# Altium schematic sheet chaves.SchDoc
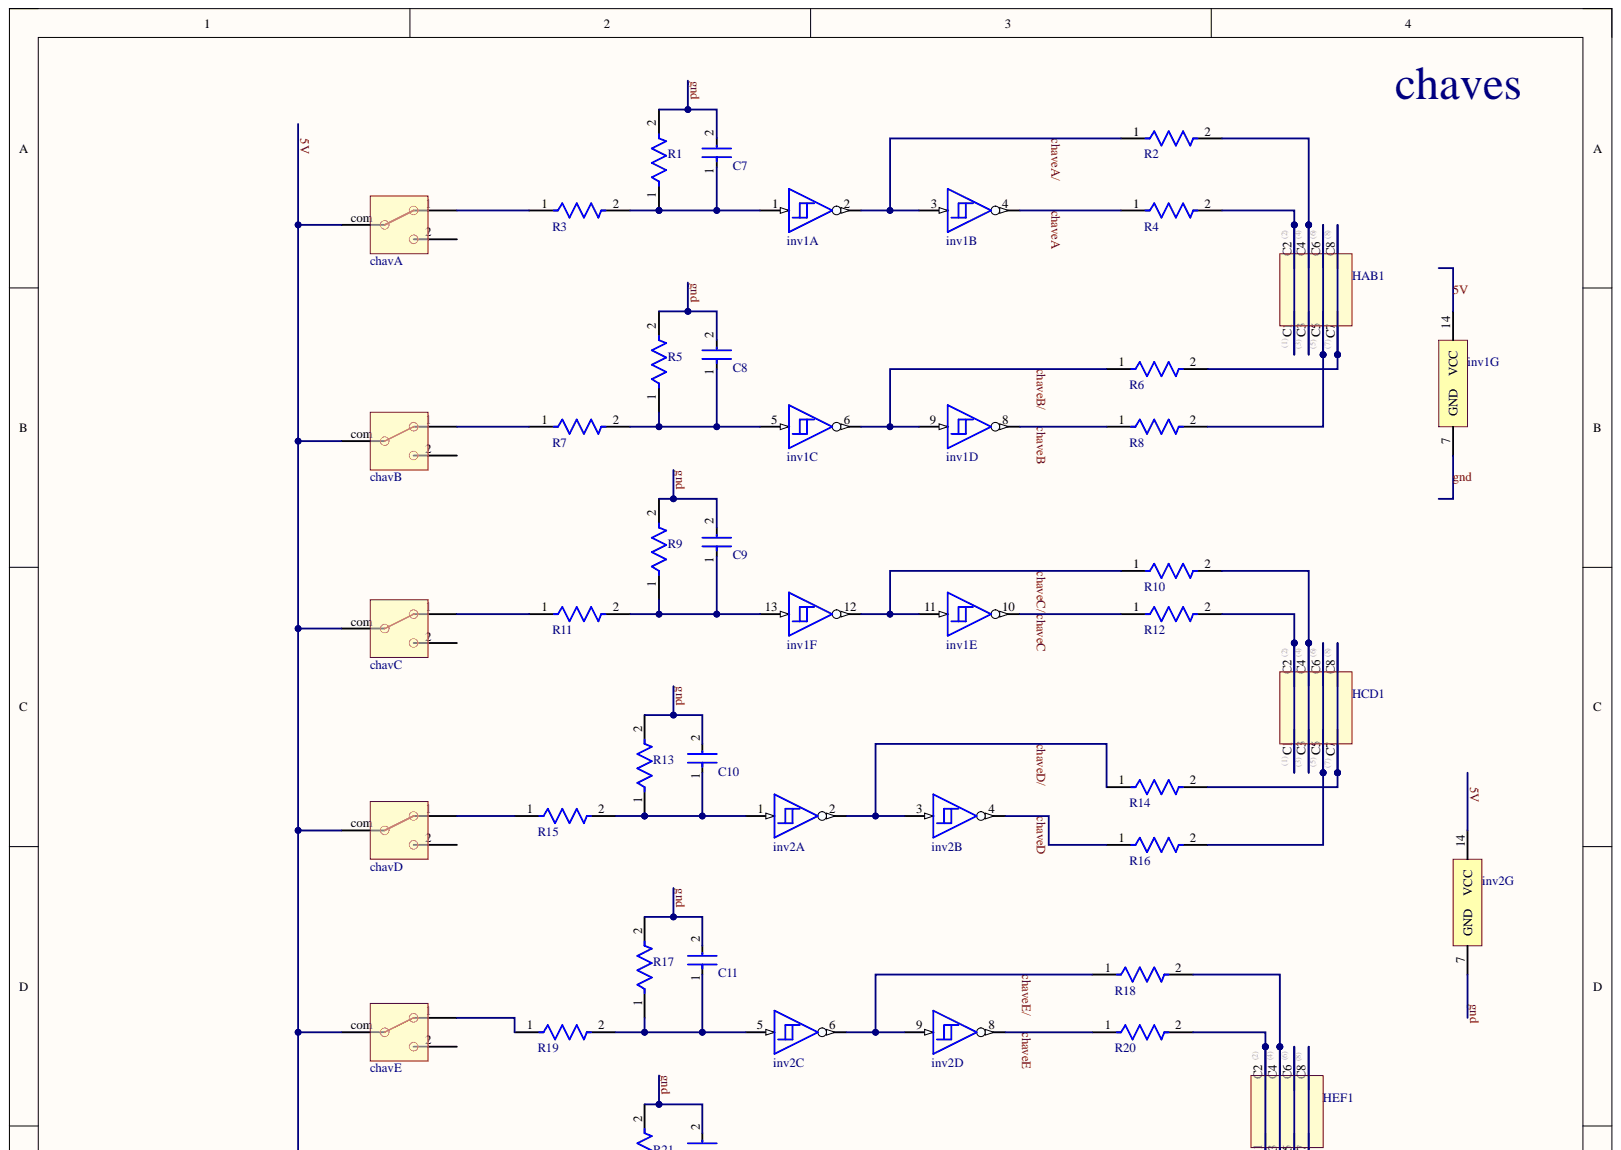
[diagram p0: chaves.SchDoc, top]
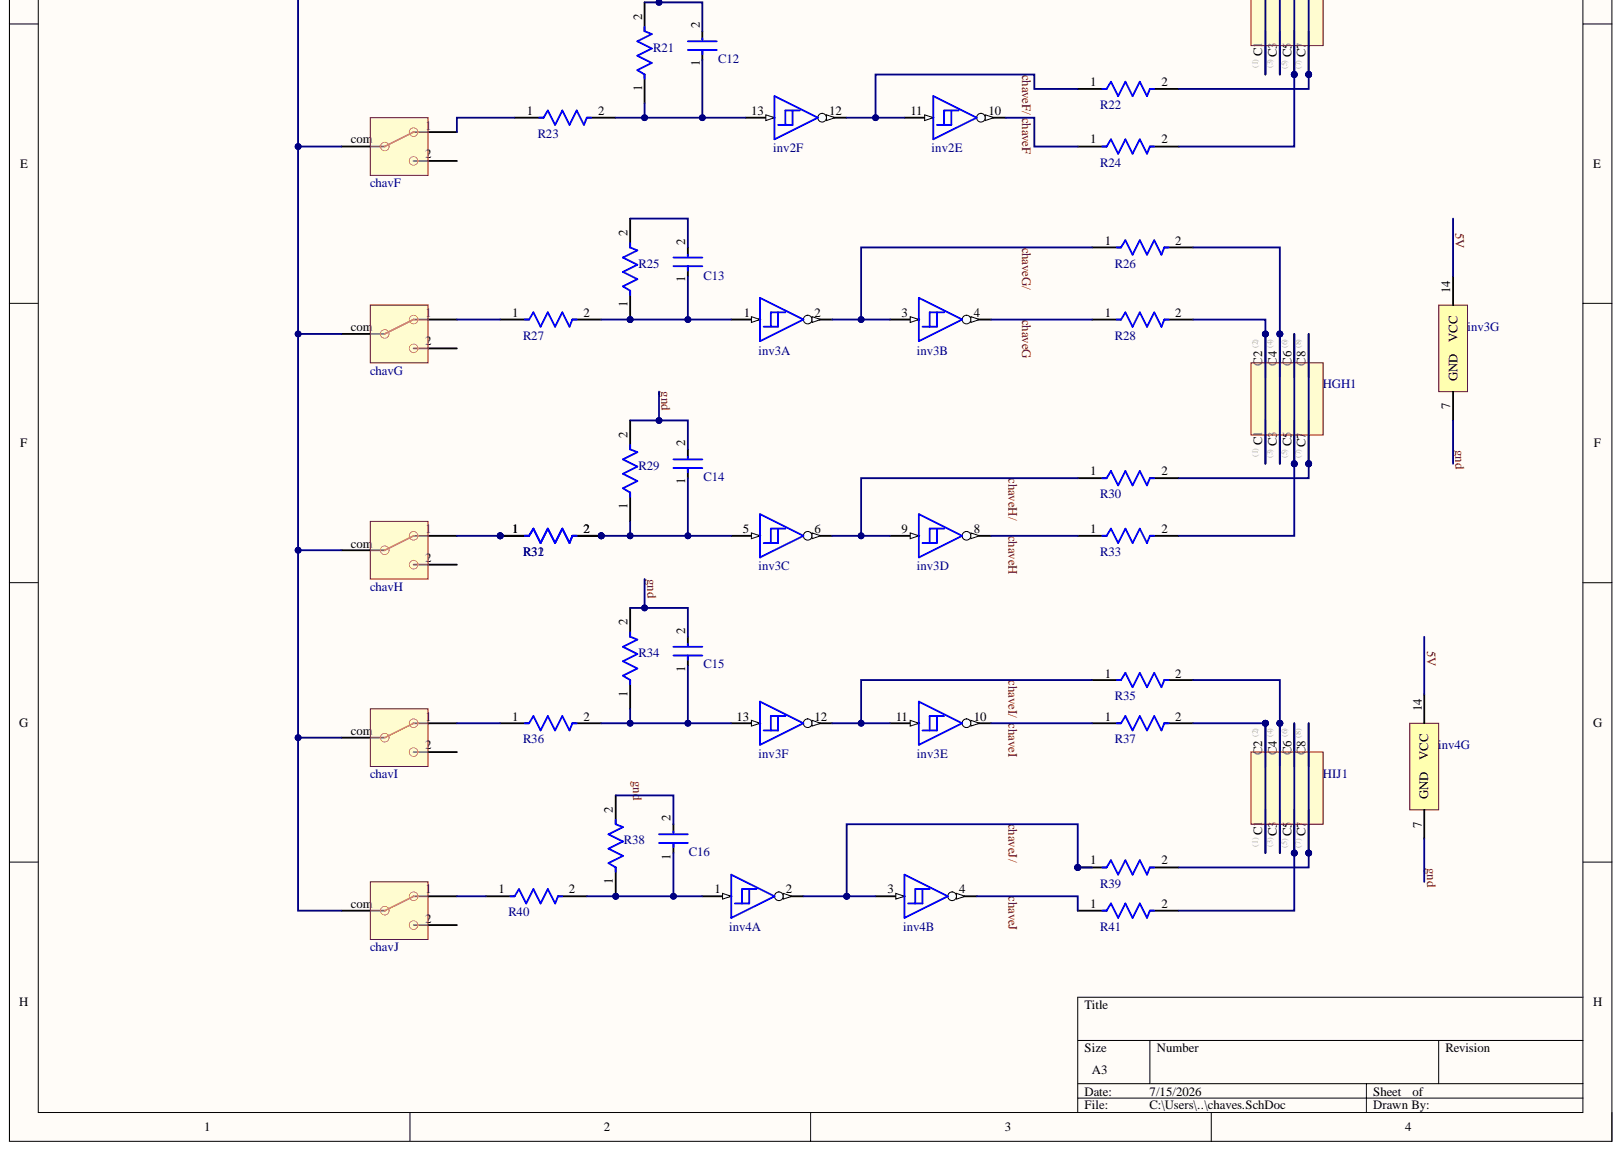
[diagram p1: chaves.SchDoc, bottom]

## Parts
C7  RCER71H335K3K1H03B  [FP-RCE3M1-MFG]  (value not drawn)
C8  RCER71H335K3K1H03B  [FP-RCE3M1-MFG]  (value not drawn)
C9  RCER71H335K3K1H03B  [FP-RCE3M1-MFG]  (value not drawn)
C10  RCER71H335K3K1H03B  [FP-RCE3M1-MFG]  (value not drawn)
C11  RCER71H335K3K1H03B  [FP-RCE3M1-MFG]  (value not drawn)
C12  RCER71H335K3K1H03B  [FP-RCE3M1-MFG]  (value not drawn)
C13  RCER71H335K3K1H03B  [FP-RCE3M1-MFG]  (value not drawn)
C14  RCER71H335K3K1H03B  [FP-RCE3M1-MFG]  (value not drawn)
C15  RCER71H335K3K1H03B  [FP-RCE3M1-MFG]  (value not drawn)
C16  RCER71H335K3K1H03B  [FP-RCE3M1-MFG]  (value not drawn)
chavA  chave MTS-102  [MTS-102]  (value not drawn)
chavB  chave MTS-102  [MTS-102]  (value not drawn)
chavC  chave MTS-102  [MTS-102]  (value not drawn)
chavD  chave MTS-102  [MTS-102]  (value not drawn)
chavE  chave MTS-102  [MTS-102]  (value not drawn)
chavF  chave MTS-102  [MTS-102]  (value not drawn)
chavG  chave MTS-102  [MTS-102]  (value not drawn)
chavH  chave MTS-102  [MTS-102]  (value not drawn)
chavI  chave MTS-102  [MTS-102]  (value not drawn)
chavJ  chave MTS-102  [MTS-102]  (value not drawn)
HAB1  header 2x4  [header 2x4]  (value not drawn)
HCD1  header 2x4  [header 2x4]  (value not drawn)
HEF1  header 2x4  [header 2x4]  (value not drawn)
HGH1  header 2x4  [header 2x4]  (value not drawn)
HIJ1  header 2x4  [header 2x4]  (value not drawn)
inv1  SN74HC14N  [N0014A]  (value not drawn)  (parts A,B,C,D,E,F,G)
inv2  SN74HC14N  [N0014A]  (value not drawn)  (parts A,B,C,D,E,F,G)
inv3  SN74HC14N  [N0014A]  (value not drawn)  (parts A,B,C,D,E,F,G)
inv4  SN74HC14N  [N0014A]  (value not drawn)  (parts A,B,G)
R1  MRS16000C2002FCT00  [FP-MRS1600-MFG]  (value not drawn)
R2  MRS16000C2002FCT00  [FP-MRS1600-MFG]  (value not drawn)
R3  MRS16000C2002FCT00  [FP-MRS1600-MFG]  (value not drawn)
R4  MRS16000C2002FCT00  [FP-MRS1600-MFG]  (value not drawn)
R5  MRS16000C2002FCT00  [FP-MRS1600-MFG]  (value not drawn)
R6  MRS16000C2002FCT00  [FP-MRS1600-MFG]  (value not drawn)
R7  MRS16000C2002FCT00  [FP-MRS1600-MFG]  (value not drawn)
R8  MRS16000C2002FCT00  [FP-MRS1600-MFG]  (value not drawn)
R9  MRS16000C2002FCT00  [FP-MRS1600-MFG]  (value not drawn)
R10  MRS16000C2002FCT00  [FP-MRS1600-MFG]  (value not drawn)
R11  MRS16000C2002FCT00  [FP-MRS1600-MFG]  (value not drawn)
R12  MRS16000C2002FCT00  [FP-MRS1600-MFG]  (value not drawn)
R13  MRS16000C2002FCT00  [FP-MRS1600-MFG]  (value not drawn)
R14  MRS16000C2002FCT00  [FP-MRS1600-MFG]  (value not drawn)
R15  MRS16000C2002FCT00  [FP-MRS1600-MFG]  (value not drawn)
R16  MRS16000C2002FCT00  [FP-MRS1600-MFG]  (value not drawn)
R17  MRS16000C2002FCT00  [FP-MRS1600-MFG]  (value not drawn)
R18  MRS16000C2002FCT00  [FP-MRS1600-MFG]  (value not drawn)
R19  MRS16000C2002FCT00  [FP-MRS1600-MFG]  (value not drawn)
R20  MRS16000C2002FCT00  [FP-MRS1600-MFG]  (value not drawn)
R21  MRS16000C2002FCT00  [FP-MRS1600-MFG]  (value not drawn)
R22  MRS16000C2002FCT00  [FP-MRS1600-MFG]  (value not drawn)
R23  MRS16000C2002FCT00  [FP-MRS1600-MFG]  (value not drawn)
R24  MRS16000C2002FCT00  [FP-MRS1600-MFG]  (value not drawn)
R25  MRS16000C2002FCT00  [FP-MRS1600-MFG]  (value not drawn)
R26  MRS16000C2002FCT00  [FP-MRS1600-MFG]  (value not drawn)
R27  MRS16000C2002FCT00  [FP-MRS1600-MFG]  (value not drawn)
R28  MRS16000C2002FCT00  [FP-MRS1600-MFG]  (value not drawn)
R29  MRS16000C2002FCT00  [FP-MRS1600-MFG]  (value not drawn)
R30  MRS16000C2002FCT00  [FP-MRS1600-MFG]  (value not drawn)
R31  MRS16000C2002FCT00  [FP-MRS1600-MFG]  (value not drawn)
R32  MRS16000C2002FCT00  [FP-MRS1600-MFG]  (value not drawn)
R33  MRS16000C2002FCT00  [FP-MRS1600-MFG]  (value not drawn)
R34  MRS16000C2002FCT00  [FP-MRS1600-MFG]  (value not drawn)
R35  MRS16000C2002FCT00  [FP-MRS1600-MFG]  (value not drawn)
R36  MRS16000C2002FCT00  [FP-MRS1600-MFG]  (value not drawn)
R37  MRS16000C2002FCT00  [FP-MRS1600-MFG]  (value not drawn)
R38  MRS16000C2002FCT00  [FP-MRS1600-MFG]  (value not drawn)
R39  MRS16000C2002FCT00  [FP-MRS1600-MFG]  (value not drawn)
R40  MRS16000C2002FCT00  [FP-MRS1600-MFG]  (value not drawn)
R41  MRS16000C2002FCT00  [FP-MRS1600-MFG]  (value not drawn)

## Nets
5V: chavA.com chavB.com chavC.com chavD.com chavE.com chavF.com chavG.com chavH.com chavI.com chavJ.com inv1.14(VCC) inv2.14(VCC) inv3.14(VCC) inv4.14(VCC)
chaveA: inv1.4 R4.1
chaveA/: inv1.2 inv1.3 R2.1
chaveB: inv1.8 R8.1
chaveB/: inv1.6 inv1.9 R6.1
chaveC: inv1.10 R12.1
chaveC/: inv1.11 inv1.12 R10.1
chaveD: inv2.4 R16.1
chaveD/: inv2.2 inv2.3 R14.1
chaveE: inv2.8 R20.1
chaveE/: inv2.6 inv2.9 R18.1
chaveF: inv2.10 R24.1
chaveF/: inv2.11 inv2.12 R22.1
chaveG: inv3.4 R28.1
chaveG/: inv3.2 inv3.3 R26.1
chaveH: inv3.8 R33.1
chaveH/: inv3.6 inv3.9 R30.1
chaveI: inv3.10 R37.1
chaveI/: inv3.11 inv3.12 R35.1
chaveJ: inv4.4 R41.1
chaveJ/: inv4.2 inv4.3 R39.1
gnd: C7.2 C8.2 C9.2 C10.2 C11.2 C12.2 C14.2 C15.2 inv1.7(GND) inv2.7(GND) inv3.7(GND) inv4.7(GND) R1.2 R5.2 R9.2 R13.2 R17.2 R21.2 R29.2 R34.2
NetC7_1: C7.1 inv1.1 R1.1 R3.2
NetC8_1: C8.1 inv1.5 R5.1 R7.2
NetC9_1: C9.1 inv1.13 R9.1 R11.2
NetC10_1: C10.1 inv2.1 R13.1 R15.2
NetC11_1: C11.1 inv2.5 R17.1 R19.2
NetC12_1: C12.1 inv2.13 R21.1 R23.2
NetC13_1: C13.1 inv3.1 R25.1 R27.2
NetC13_2: C13.2 R25.2
NetC14_1: C14.1 inv3.5 R29.1 R31.2 R32.2
NetC15_1: C15.1 inv3.13 R34.1 R36.2
NetC16_1: C16.1 inv4.1 R38.1 R40.2
NetC16_2: C16.2 R38.2
NetchavA_1: chavA.1 R3.1
NetchavB_1: chavB.1 R7.1
NetchavC_1: chavC.1 R11.1
NetchavD_1: chavD.1 R15.1
NetchavE_1: chavE.1 R19.1
NetchavF_1: chavF.1 R23.1
NetchavG_1: chavG.1 R27.1
NetchavH_1: chavH.1 R31.1 R32.1
NetchavI_1: chavI.1 R36.1
NetchavJ_1: chavJ.1 R40.1
NetHAB1_1: HAB1.1 HAB1.2 R4.2
NetHAB1_3: HAB1.3 HAB1.4 R2.2
NetHAB1_5: HAB1.5 HAB1.6 R8.2
NetHAB1_7: HAB1.7 HAB1.8 R6.2
NetHCD1_1: HCD1.1 HCD1.2 R12.2
NetHCD1_3: HCD1.3 HCD1.4 R10.2
NetHCD1_5: HCD1.5 HCD1.6 R16.2
NetHCD1_7: HCD1.7 HCD1.8 R14.2
NetHEF1_1: HEF1.1 HEF1.2 R20.2
NetHEF1_3: HEF1.3 HEF1.4 R18.2
NetHEF1_5: HEF1.5 HEF1.6 R24.2
NetHEF1_7: HEF1.7 HEF1.8 R22.2
NetHGH1_1: HGH1.1 HGH1.2 R28.2
NetHGH1_3: HGH1.3 HGH1.4 R26.2
NetHGH1_5: HGH1.5 HGH1.6 R33.2
NetHGH1_7: HGH1.7 HGH1.8 R30.2
NetHIJ1_1: HIJ1.1 HIJ1.2 R37.2
NetHIJ1_3: HIJ1.3 HIJ1.4 R35.2
NetHIJ1_5: HIJ1.5 HIJ1.6 R41.2
NetHIJ1_7: HIJ1.7 HIJ1.8 R39.2

Not connected: chavA 2; chavB 2; chavC 2; chavD 2; chavE 2; chavF 2; chavG 2; chavH 2; chavI 2; chavJ 2

## Library data of the parts
C7-C12 = CMP-06035-028451-1: Cap Ceramic 3.3uF 50V X7R ±10% Radial 5mm +125°C Automotive Ammo Pack; Capacitance: 100nF; Lead Diameter: 0.5mm; Lead/Base Style: Formed Leads; Case/Package: Radial; Component Kind: Standard; Dielectric: X7R; Height: 5mm; Height - Seated (Max): 0.197inch; Lead Pitch: 0.197inch; Length: 0.217inch; Library Name: Altium Content Vault; Max Operating Temperature: 125°C; Min Operating Temperature: -55°C; Mount: Through Hole; Package Quantity: 500; Packaging: Bulk; Ratings: AEC-Q200; Resistor Type: Ceramic; Termination: Radial; Tolerance: 10%; Voltage Rating: 50V; Voltage Rating (DC): 50V; Width: 0.157inch
C13-C16 = CMP-06035-028451-1: Cap Ceramic 3.3uF 50V X7R ±10% Radial 5mm +125°C Automotive Ammo Pack; Lead Diameter: 0.5mm; Lead/Base Style: Formed Leads; Capacitance: 3.3uF; Case/Package: Radial; Component Kind: Standard; Dielectric: X7R; Height: 5mm; Height - Seated (Max): 0.197inch; Lead Pitch: 0.197inch; Length: 0.217inch; Library Name: Altium Content Vault; Max Operating Temperature: 125°C; Min Operating Temperature: -55°C; Mount: Through Hole; Package Quantity: 500; Packaging: Bulk; Ratings: AEC-Q200; Resistor Type: Ceramic; Termination: Radial; Tolerance: 10%; Voltage Rating: 50V; Voltage Rating (DC): 50V; Width: 0.157inch
chavA chavB chavC chavD chavE chavF chavG chavH chavI chavJ = MTS-102: chave caso seja usadsada sendo encaixada; Component Kind: Standard; Library Name: Schlib1.SchLib; Parameter 1: MTS-102
HAB1 HCD1 HEF1 HGH1 HIJ1 = header 2x4: header male 2x4; Component Kind: Standard; Library Name: Schlib1.SchLib; Parameter 1: header 2x4
inv1-inv4 = CMP-1615-00889-3: Hex Schmitt-Trigger Inverters, N0014A, TUBE; Manufacturer: Texas Instruments; Bits(#): 6; F @ Nom Voltage(Max)(Mhz): 20; ICC @ Nom Voltage(Max)(mA): 0.02; Input Type: CMOS; Output Drive (IOL/IOH)(Max)(mA): -4/4; Rating: Catalog; Schmitt Trigger: Yes; Technology Family: HC; tpd @ Nom Voltage(Max)(ns): 31; VCC(Min)(V): 2; Voltage(Nom)(V): 5; Code_JEDEC: MS-001; Component Kind: Standard; DatasheetVersion: SCLS085G; Generic Part Number: SN74HC14; Library Name: Altium Content Vault; Operating Temperature Range(C): -40 to 85; Output Type: CMOS; PackageReference: N0014A; VCC(Max)(V): 6
R1 R5 R9 R13 R17 R21 R25 R29 R32 R34 R38 = CMP-02408-000019-1: RES 20K OHM 0.4W 1% AXIAL; Max Power Dissipation: 400mW; Resistance: 20kR; Temperature Coefficient: 50ppm/°C; Case/Package: Conformal; Component Kind: Standard; Construction: Cylindrical; Contact Plating: Tin; Diameter: 1.6mm; Length: 3.6mm; Library Name: Altium Content Vault; Max Operating Temperature: 155°C; Military Standard: Not; Min Operating Temperature: -55°C; Mount: Through Hole; Number of Terminations: 2; Packaging: Tape and Reel; Power Rating: 400mW; Radiation Hardening: No; Resistor Type: Metal Film; RoHS Compliant: Yes; Series: MRS16; Technology: THIN FILM; Termination: Axial; Tolerance: 1%
R2 R4 R6 R8 R10 R12 R14 R16 R18 R20 R22 R24 R26 R28 R30 R33 R35 R37 R39 R41 = CMP-02408-000019-1: RES 1K OHM 0.4W 1% AXIAL; Max Power Dissipation: 400mW; Resistance: 1kR; Temperature Coefficient: 50ppm/°C; Case/Package: Conformal; Component Kind: Standard; Construction: Cylindrical; Contact Plating: Tin; Diameter: 1.6mm; Length: 3.6mm; Library Name: Altium Content Vault; Max Operating Temperature: 155°C; Military Standard: Not; Min Operating Temperature: -55°C; Mount: Through Hole; Number of Terminations: 2; Packaging: Tape and Reel; Power Rating: 400mW; Radiation Hardening: No; Resistor Type: Metal Film; RoHS Compliant: Yes; Series: MRS16; Technology: THIN FILM; Termination: Axial; Tolerance: 1%
R3 = CMP-02408-000019-1: RES 1K OHM 0.4W 1% AXIAL; Max Power Dissipation: 400mW; Resistance: 330R; Temperature Coefficient: 50ppm/°C; Case/Package: Conformal; Component Kind: Standard; Construction: Cylindrical; Contact Plating: Tin; Diameter: 1.6mm; Length: 3.6mm; Library Name: Altium Content Vault; Max Operating Temperature: 155°C; Military Standard: Not; Min Operating Temperature: -55°C; Mount: Through Hole; Number of Terminations: 2; Packaging: Tape and Reel; Power Rating: 400mW; Radiation Hardening: No; Resistor Type: Metal Film; RoHS Compliant: Yes; Series: MRS16; Technology: THIN FILM; Termination: Axial; Tolerance: 1%
R7 R11 R15 R19 R23 R27 R31 R36 R40 = CMP-02408-000019-1: RES 330 OHM 0.4W 1% AXIAL; Max Power Dissipation: 400mW; Resistance: 330; Temperature Coefficient: 50ppm/°C; Case/Package: Conformal; Component Kind: Standard; Construction: Cylindrical; Contact Plating: Tin; Diameter: 1.6mm; Length: 3.6mm; Library Name: Altium Content Vault; Max Operating Temperature: 155°C; Military Standard: Not; Min Operating Temperature: -55°C; Mount: Through Hole; Number of Terminations: 2; Packaging: Tape and Reel; Power Rating: 400mW; Radiation Hardening: No; Resistor Type: Metal Film; RoHS Compliant: Yes; Series: MRS16; Technology: THIN FILM; Termination: Axial; Tolerance: 1%
